# Altium schematic sheet Main.SchDoc(Main)
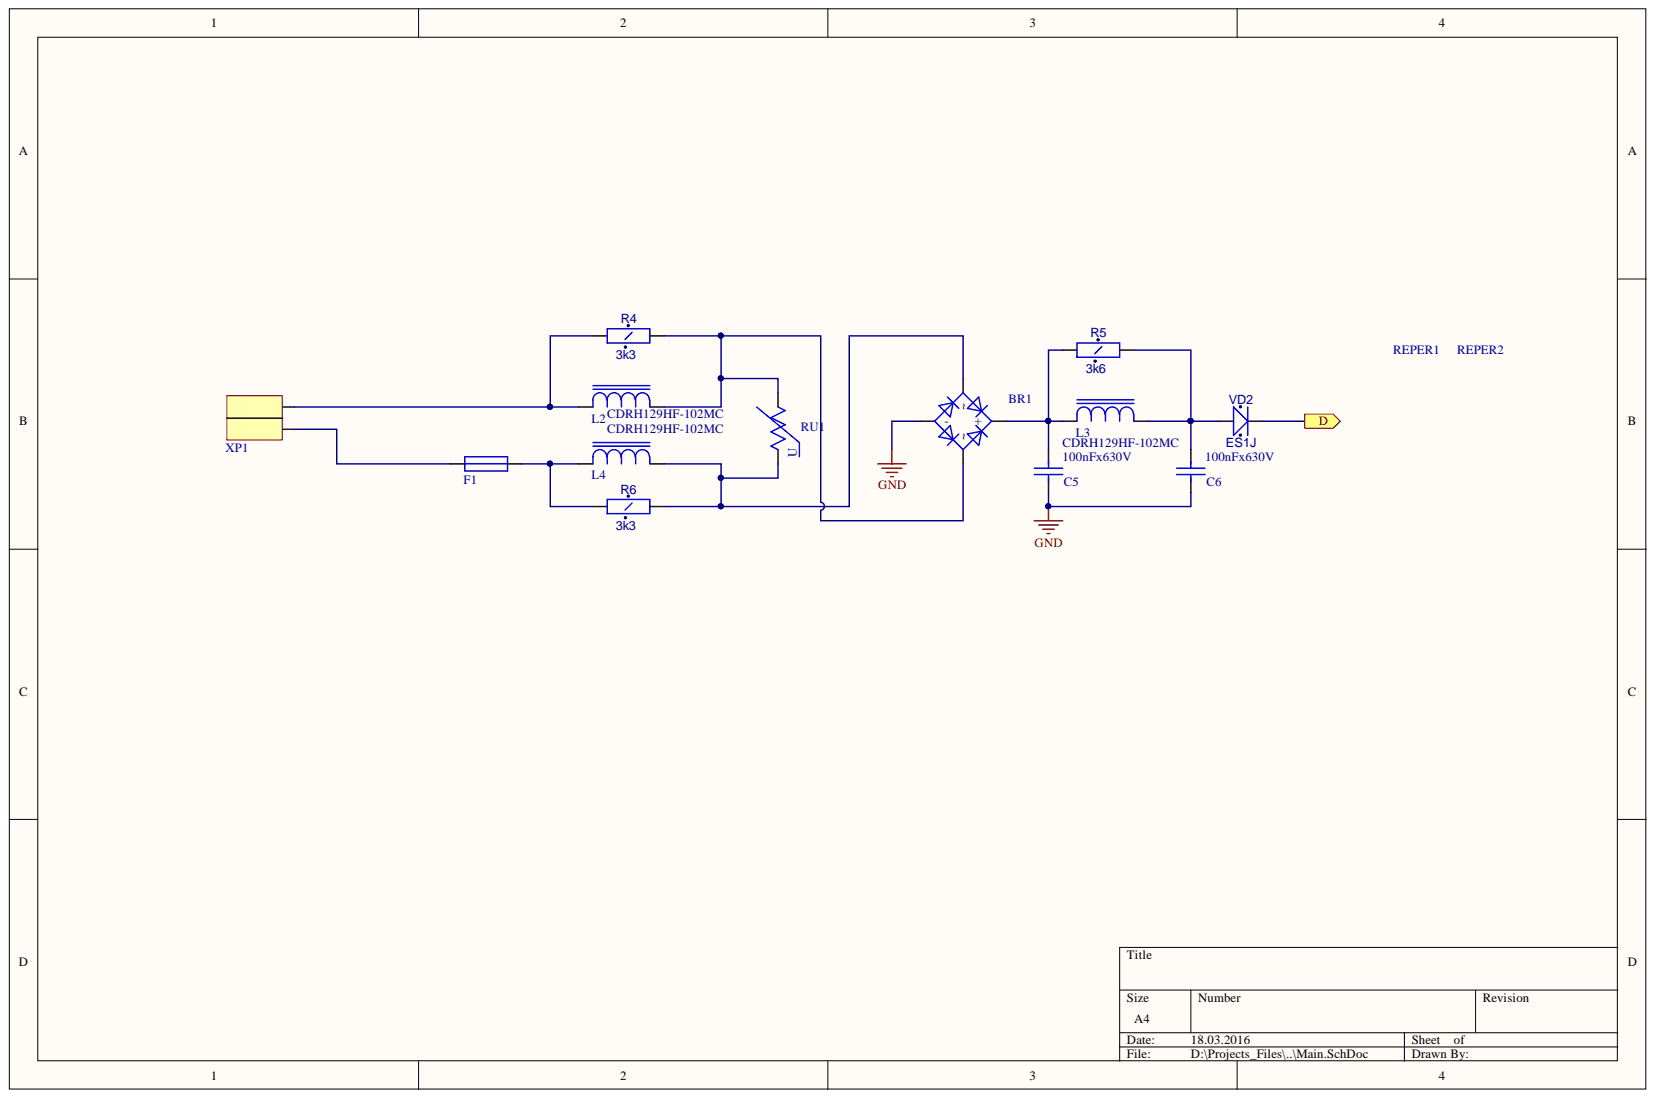
[diagram p0: Main.SchDoc]

## Parts
BR1  DF10S  [SDIP]  (value not drawn)
C5  100nFx630V  [CAP_630V_SMD]
C6  100nFx630V  [CAP_630V_SMD]
F1  (no value)  [Fuse Belfuse]
L2  CDRH129HF-102MC  [IND_CDRH129]
L3  CDRH129HF-102MC  [IND_CDRH129]
L4  CDRH129HF-102MC  [IND_CDRH129]
R4  3k3  [RC1206]
R5  3k6  [RC1206]
R6  3k3  [RC1206]
REPER1  REPER1.2  [REPER1.2]  (value not drawn)
REPER2  REPER1.2  [REPER1.2]  (value not drawn)
RU1  KLS6-07D  [Varistor_7mm_SMD]  (value not drawn)
VD2  ES1J  [SMA]
XP1  (no value)  [Poke-In 2pin]

## Ports
D

## Nets
GND: BR1.2(-) C5.1 C6.1
D: VD2.2 ; port D
NetBR1_1: BR1.1(+) C5.2 L3.1 R5.1
NetBR1_3: BR1.3(~) L4.2 R6.2 RU1.1
NetBR1_4: BR1.4(~) L2.2 R4.2 RU1.2
NetC6_2: C6.2 L3.2 R5.2 VD2.1
NetF1_1: F1.1 XP1.4
NetF1_2: F1.2 L4.1 R6.1
NetL2_1: L2.1 R4.1 XP1.3

## Library data of the parts
C5 C6 = Cap_HV: Component Kind: Standard; Value: 100nFx630V; Library Name: gprs_modem_2.SchLib
F1 = Fuse Belufse: Component Kind: Standard; Library Name: gprs_modem_2.SchLib
L2-L4 = CDRH129: Component Kind: Standard; Value: CDRH129HF-102MC; Library Name: gprs_modem_2.SchLib
R4-R6 = RC1206: Component Kind: Standard; Description: RC1206; Library Name: gprs_modem_2.SchLib
  R4 R6: Value: 3k3
  R5: Value: 3k6
VD2 = SS14: Schottky Rectifier; ComponentField6: Published 8-Jun-2000, Modified 10-July-2000: SIM Model Added.; OriginalName: 10BQ100; Component Kind: Standard; Simulation: 10BQ100; Value: ES1J; Library Name: gprs_modem_2.SchLib
XP1 = Poke-IN 2Pin: Component Kind: Standard; Library Name: gprs_modem_2.SchLib
BR1 = DF10S: Component Kind: Standard; Value: DF10S; Library Name: gprs_modem_2.SchLib
REPER1 REPER2 = REPER1.2: Component Kind: Standard; Description: REPER1.2; Library Name: gprs_modem.SchLib
RU1 = KLS6-07D: Component Kind: Standard; Value: KLS6-07D; Library Name: gprs_modem_2.SchLib
